# Altium schematic sheet ALTERA_MAX10_ARDUINO_BOARD_CLK.SchDoc(ALTERA_MAX10_ARDUINO_BOARD_CLK)
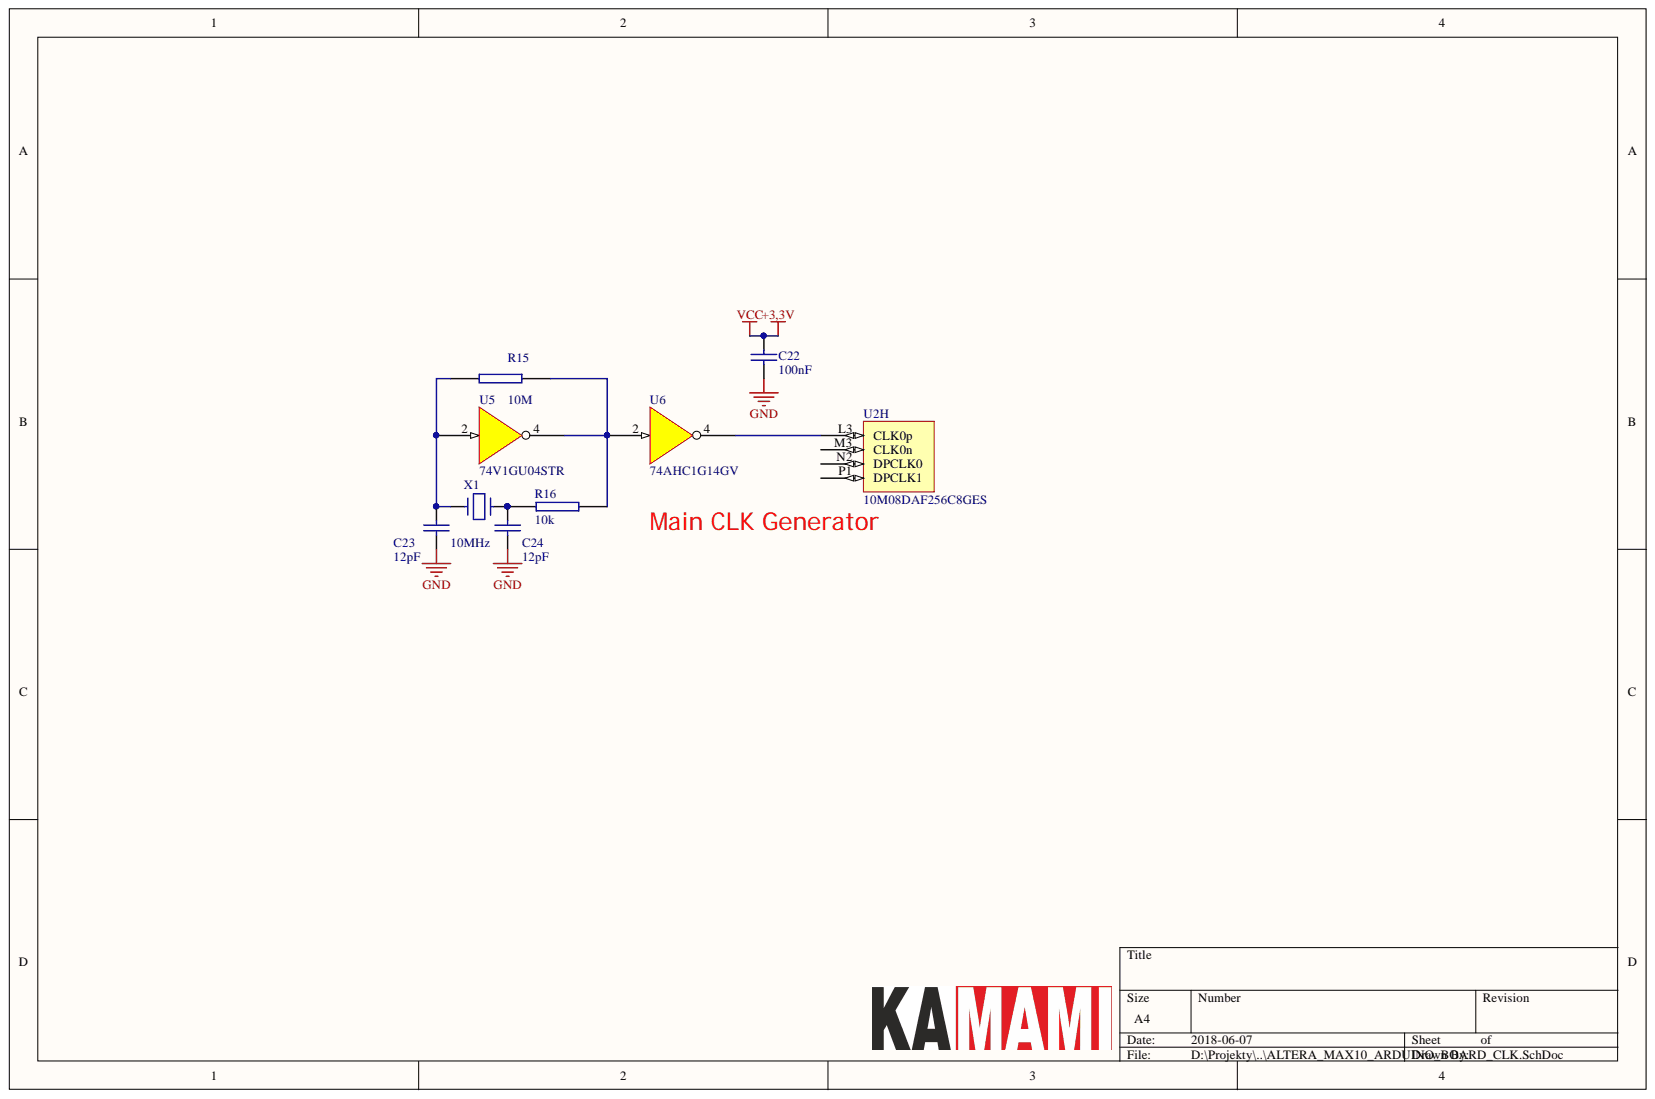
[diagram p0: ALTERA_MAX10_ARDUINO_BOARD_CLK.SchDoc]

## Parts
C22  100nF  [0603C]
C23  12pF  [0603C]
C24  12pF  [0603C]
R15  10M  [0603R]
R16  10k  [0603R]
U2  10M08DAF256C8GES  [BGA256C100P16X16_1700X1700X145]  (part H)
U5  74V1GU04STR  [SOT23-5]
U6  74AHC1G14GV  [SOT23-5]
X1  10MHz  [CRYSTAL_SMD_5x3.2]

## Nets
+3,3V: C22.1 U5.5 U6.5
GND: C22.2 C23.2 C24.2 U5.3 U6.3
NetC23_1: C23.1 R15.1 U5.2 X1.1
NetC24_1: C24.1 R16.1 X1.2
NetR15_2: R15.2 R16.2 U5.4 U6.2
NetU2_L3: U2.L3(CLK0p) U6.4

Not connected: U2 M3(CLK0n) N2(DPCLK0) P1(DPCLK1)

## Library data of the parts
C22 = CAP: Capacitor; Library Name: Miscellaneous Devices.SchLib; Component Kind: Standard
C23 C24 = CAP np: Capacitor; Library Name: Miscellaneous Devices.SchLib; Component Kind: Standard
R15 R16 = res2: Library Name: Miscellaneous Devices.SchLib; Component Kind: Standard
U5 U6 = 74V1G04: Component Kind: Standard; Library Name: SingleGates.SchLib
X1 = CRYSTAL: Library Name: Miscellaneous Devices.SchLib; Description: Crystal; Component Kind: Standard
U2 = 10M08DAF256C8GES: Library Name: Altera_MAX10.SchLib; Component Kind: Standard
